# Altium schematic sheet power_supply.SchDoc
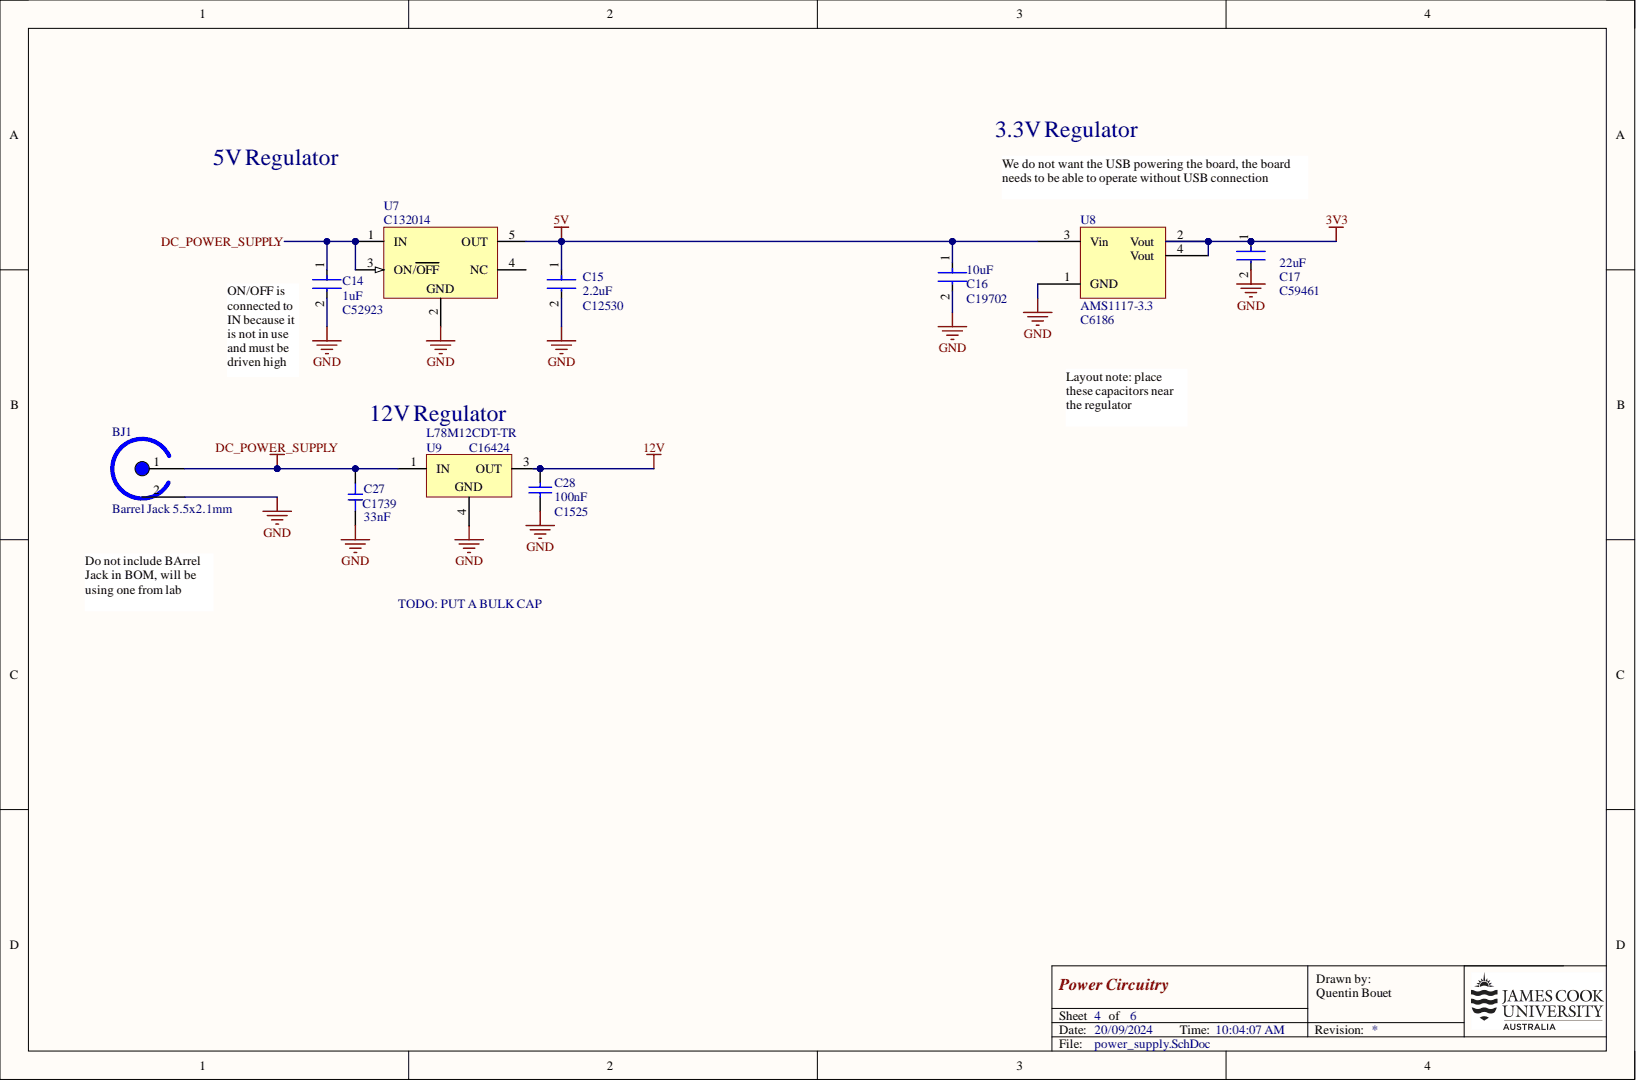
[diagram p0: power_supply.SchDoc]

## Parts
BJ1  Barrel Jack 5.5x2.1mm  [Barrel Jack 2.1x5.5mm THT]
C14  Capacitance: 1uF  [FP-CL542-IPC_B]  (hidden comment: CL05A105KA5NQNC)
C15  Capacitance: 2.2uF  JLCPCB Part: C12530  [FP-CL50-IPC_C]  (hidden comment: CL05A225MQ5NSNC)
C16  Capacitance: 10uF  JLCPCB Part: C19702  [FP-CL10-IPC_B]  (hidden comment: CL10A106KP8NNNC)
C17  Capacitance: 22uF  JLCPCB Part: C59461  [FP-CL10-IPC_B]  (hidden comment: CL10A226MQ8NRNC)
C27  Capacitance: 33nF  JLCPCB Part: C1739  [CAP-0805]  (hidden comment: 0805B333K500NT)
C28  Capacitance: 100nF  JLCPCB Part: C1525  [CAPC1050X55X23NL05T13]  (hidden comment: CL05B104KO5NNNC)
U7  JLCPCB Part: C132014  [MF05A_M]  (hidden comment: LP2980AIM5-5.0/NOPB)
U8  AMS1117-3.3  JLCPCB Part: C6186  [SOT230P700X180-4N]
U9  L78M12CDT-TR  JLCPCB Part: C16424  [TO-252_L]

## Nets
3V3: C17.1 U8.2(Vout) U8.4(Vout)
5V: C15.1 C16.1 U7.5(OUT) U8.3(Vin)
12V: C28.2 U9.3
GND: BJ1.2 C14.2 C15.2 C16.2 C17.2 C27.1 C28.1 U7.2 U8.1(GND) U9.4
DC_POWER_SUPPLY: BJ1.1 C14.1 C27.2 U7.1(IN) U7.3(ON/OFF) U9.1
NetU7_4: U7.4(NC)

## Library data of the parts
BJ1 = CMP-005-0005-1: GCT DCJ200-10-A-K1-K; Component Kind: Standard; Component Type: Standard; Design Item ID: Barrel Jack 5.5x2.1mm; JLCPCB Part: jlcpcb part no.; Pins: 2; Library Name: James Cook University; Source: JCULibrary.SchLib
C14 = CMP-00005-3: Cap Ceramic 1uF 25V X5R ±10% SMD 0402 +85°C Paper T/R; Case Code (Imperial): 0402; Case Code (Metric): 1005; Case/Package: 0402; Component Kind: Standard; Depth: 0.5mm; Dielectric: X5R; Height: 0.5mm; JLCPCB Part: C52923; Lead Free: Lead Free; Length: 1mm; Material: Ceramic; Max Operating Temperature: 85°C; Min Operating Temperature: -55°C; Mount: Surface Mount; Package Quantity: 10000; Packaging: Tape and Reel; Radiation Hardening: No; Thickness: 0.022inch; Tolerance: 10%; Voltage Rating: 25V; Width: 0.02inch; Library Name: James Cook University
C15 = CMP-13271-001039-1: Cap Ceramic 2.2uF 6.3V X5R ±20% SMD 0402 +85°C Paper T/R; Case Code (Imperial): 0402; Case Code (Metric): 1005; Case/Package: 0402; Component Kind: Standard; Depth: 0.5mm; Dielectric: X5R; Height: 0.5mm; Lead Free: Lead Free; Length: 1mm; Library Name: Altium Content Vault; Max Operating Temperature: 85°C; Min Operating Temperature: -55°C; Mount: Surface Mount; Package Quantity: 10000; Packaging: Tape and Reel; Radiation Hardening: No; Thickness: 0.022inch; Tolerance: 20%; Voltage Rating: 6.3V; Width: 0.02inch
C16 = CMP-00006-4: Cap Ceramic 10uF 10V X5R ±10% Pad SMD 0603 +85°C T/R; Case Code (Imperial): 0603; Case Code (Metric): 1608; Case/Package: 0603; Component Kind: Standard; Length: 0.063inch; Max Operating Temperature: 85°C; Min Operating Temperature: -55°C; Mount: Surface Mount; Packaging: Tape and Reel; Thickness: 0.041inch; Tolerance: 10%; Voltage Rating: 10V; Width: 0.031inch; Library Name: James Cook University
C17 = CMP-006-0003-1: Cap Ceramic 22uF 6.3V X5R ±20% Pad SMD 0603; Case Code (Imperial): 0603; Case Code (Metric): 1608; Case/Package: 0603; Component Kind: Standard; Length: 1.6mm; Max Operating Temperature: 85°C; Min Operating Temperature: -55°C; Mount: Surface Mount; Packaging: Tape and Reel; Thickness: 0.039inch; Tolerance: 20%; Voltage Rating: 6.3V; Width: 0.031inch; Library Name: James Cook University
C27 = CMP-001-00028-1: Capacitor, Ceramic (MLCC), 33nF, ±10%, 50V, X7R, ±10%, SMD 0805; Manufacturer: FH(Guangdong Fenghua Advanced Tech); Category: Capacitors - MLCC; Component Kind: Standard; ComponentLink1Description: Datasheet; ComponentLink1URL: https://datasheet.lcsc.com/lcsc/1811061723_FH--Guangdong-Fenghua-Advanced-Tech-0805B333K500NT_C1739.pdf; ComponentLink2Description: JLCPCB Part URL; ComponentLink2URL: https://jlcpcb.com/partdetail/C1739; ComponentLink3Description: LCSC Part URL; ComponentLink3URL: https://lcsc.com/product-detail/Multilayer-Ceramic-Capacitors-MLCC---SMD-SMT_FH-Guangdong-Fenghua-Advanced-Tech-FH-Guangdong-Fenghua-Advanced-Tech-0805B333K500NT_C1739.html; Design Item ID: 0805B333K500NT; JLCPCB Basic Part: Yes; Manufacturer Part Number: 0805B333K500NT; Package Size: 0805; RoHS: Yes; Source: jlcpcb_capacitors_basic.schlib; Temperature Coefficient: X7R; Tolerance: ±10%; Voltage Rating: 50V; Library Name: James Cook University
C28 = CMP-00007-3: Chip Capacitor, 100 nF, +/- 10%, 16 V, -55 to 125 degC, 0402 (1005 Metric), RoHS, Tape and Reel; Manufacturer: Samsung Electro-Mechanics; Dielectric: X7R; Rated Voltage: 16V; Case Size/Dimension: 0402; Component Kind: Standard; Datasheet URL: https://datasheet.ciiva.com/29198/458718-da-01-en-vs-kondensator-0402-29198423.pdf; Datasheet Version: Nov-10; Manufacturer URL: http://www.samsungsem.com/global/index.jsp; Tolerance (%): ±10%; Library Name: James Cook University
U7 = CMP-0062-02174-2: Micropower 50 mA Ultra Low-Dropout Regulator, 5-pin SOT-23, Pb-Free; Manufacturer: National Semiconductor; CompType: LDO IC; PackageTopMarking: L01A*XTT; PowerWise: N; Component Kind: Standard; ComponentLink1Description: LP2980 Datasheet; ComponentLink1URL: http://www.national.com/ds/LP/LP2980.pdf; ComponentLink2Description: LP2980 Web Page; ComponentLink2URL: http://www.national.com/pf/LP/LP2980.html; ComponentLink3Description: MF05A Package Page; ComponentLink3URL: http://www.national.com/packaging/folders/mf05a.html; DatasheetVersion: Sep-2006; Library Name: Altium Content Vault; PackageDescription: Molded SOT-23, .115 x .063 x .040 in Body, 5 Lead, .0375 in Pitch; PackageReference: MF05A; PackageVersion: revD, Aug-2008; PinCount: 5; RevisionNote: Component URLs added; RoHS: Y
U8 = CMP-00001-4: 3.3V 1A LOW DROPOUT VOLTAGE REGULATOR SOT-223; Dropout voltage: 1.3 V; Component Kind: Standard; Output current: 1 A; Output voltage: 3.3 V; Library Name: James Cook University
U9 = CMP-0244-00204-1: Positive Voltage Regulator, 12V, 3-Pin DPAK, Tape and Reel; Component Kind: Standard; ComponentLink1Description: Manufacturer URL; ComponentLink1URL: http://www.st.com; ComponentLink2Description: Datasheet; ComponentLink2URL: http://www.st.com/internet/com/TECHNICAL_RESOURCES/TECHNICAL_LITERATURE/DATASHEET/CD00000447.pdf; DatasheetVersion: 1/11/2010; Library Name: Altium Content Vault; PackageDescription: 3-Pin Transistor Outline Package 2.28 mm Pitch, 9.7 mm Lead Span (DPAK); PackageReference: TO-252; PackageVersion: 2011
